# Altium schematic sheet Nucleo-64.SchDoc
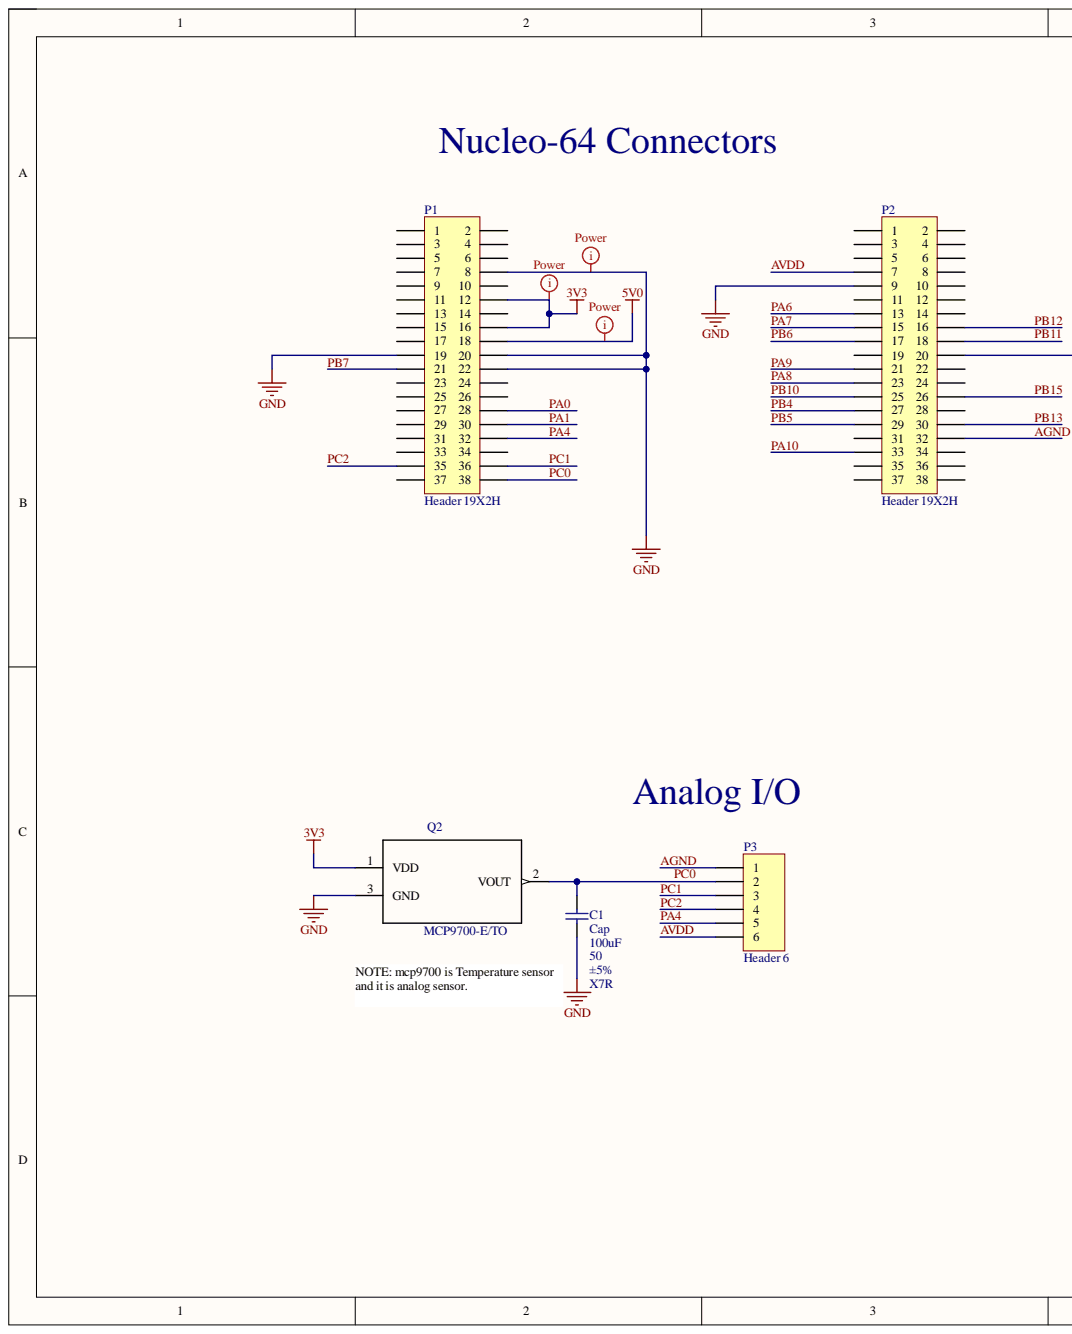
[diagram p0: Nucleo-64.SchDoc, left]
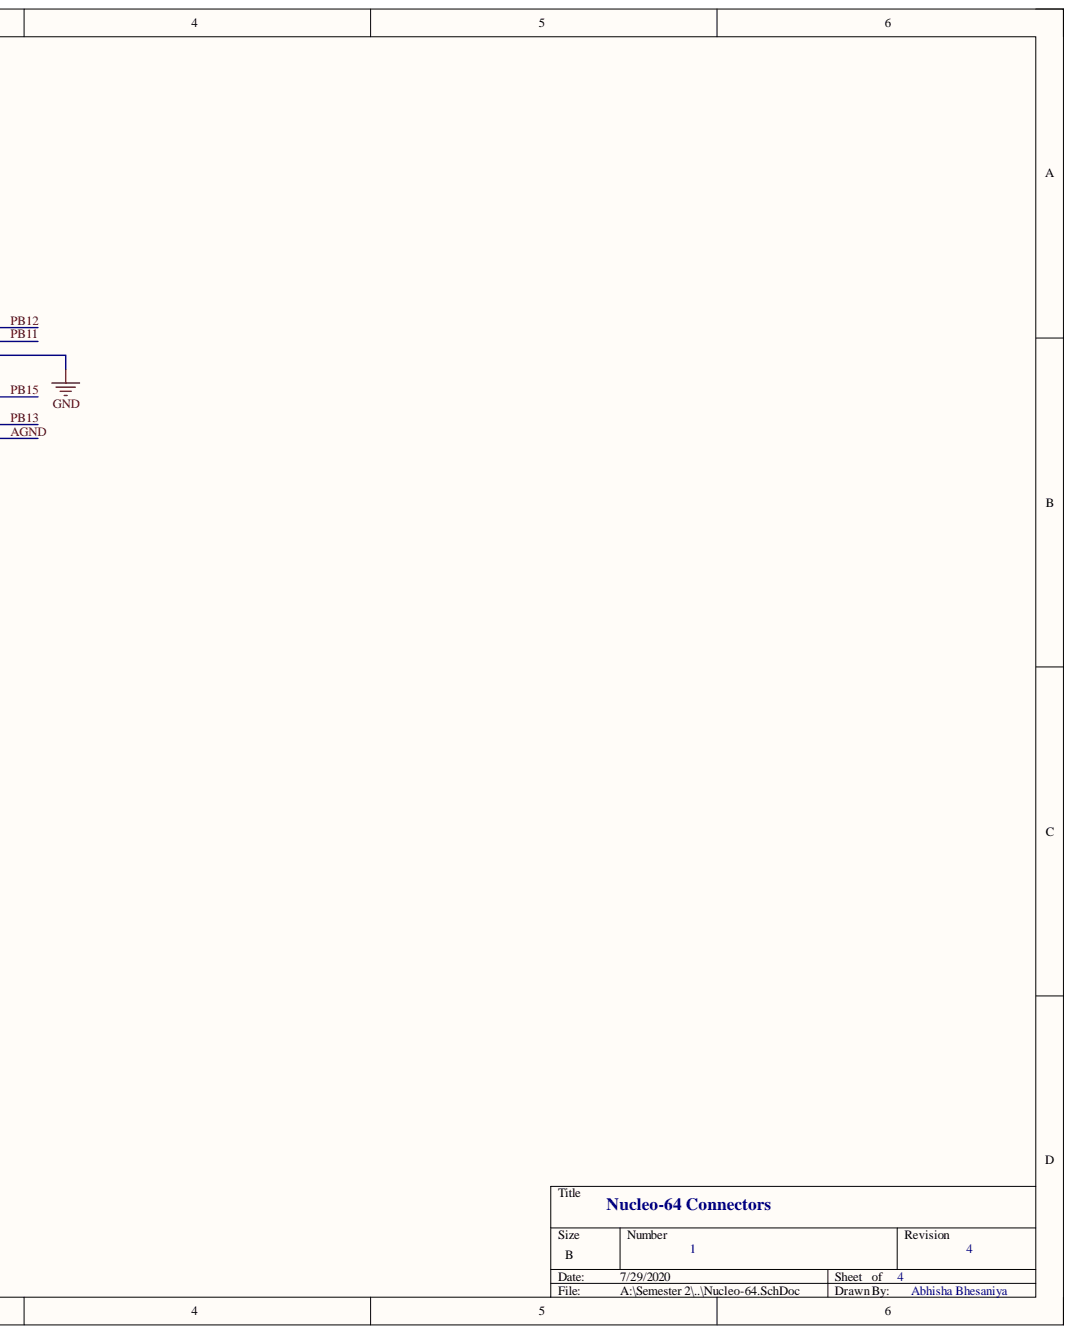
[diagram p1: Nucleo-64.SchDoc, right]

## Parts
C1  Cap  value 100uF  Tolerance: ±5%  [CAPC2012X09M]
P1  Header 19X2H  [PPPC192LFBN-RC]
P2  Header 19X2H  [PPPC192LFBN-RC]
P3  Header 6  [HDR1X6]
Q2  MCP9700-E/TO  [*TO127P254X533-3]

## Nets
3V3: P1.12 P1.16 Q2.1
5V0: P1.18
GND: C1.1 P1.8 P1.19 P1.20 P1.22 P2.9 P2.20 Q2.3
AGND_1: P2.32 P3.1  [also labelled AGND]
AVDD_1: P2.7 P3.6  [also labelled AVDD]
PA0_1: P1.28  [also labelled PA0]
PA1_1: P1.30  [also labelled PA1]
PA4_1: P1.32 P3.5  [also labelled PA4]
PA6_1: P2.13  [also labelled PA6]
PA7_1: P2.15  [also labelled PA7]
PA8_1: P2.23  [also labelled PA8]
PA9_1: P2.21  [also labelled PA9]
PA10_1: P2.33  [also labelled PA10]
PB4_1: P2.27  [also labelled PB4]
PB5_1: P2.29  [also labelled PB5]
PB6_1: P2.17  [also labelled PB6]
PB7_1: P1.21  [also labelled PB7]
PB10_1: P2.25  [also labelled PB10]
PB11_1: P2.18  [also labelled PB11]
PB12_1: P2.16  [also labelled PB12]
PB13_1: P2.30  [also labelled PB13]
PB15_1: P2.26  [also labelled PB15]
PC0_1: C1.2 P1.38 P3.2 Q2.2  [also labelled PC0]
PC1_1: P1.36 P3.3  [also labelled PC1]
PC2_1: P1.35 P3.4  [also labelled PC2]

Not connected: P1 1 2 3 4 5 6 7 9 10 11 13 14 15 17 23 24 25 26 27 29 31 33 34 37; P2 1 2 3 4 5 6 8 10 11 12 14 19 22 24 28 31 34 35 36 37 38

## Library data of the parts
C1 = CAP-X7R-.1uF-50V-±5%: CAP CER .1uF 50v X7R 0805; MPN: C0805C104J5RACTU; PN: 399-1171-1-ND; WV: 50; Component Kind: Standard; Library Name: esd_library.IntLib; Name: CAP-X7R-.1uF-50V-±5%; Type: X7R; URL: http://www.digikey.ca/product-detail/en/C0805C104J5RACTU/399-1171-1-ND
P1 P2 = Header 19X2H: 38 Position Header Connector 0.100in (2.54mm) Through Hole Gold; PN: S7122-ND; Component Kind: Standard; Library Name: esd_library.IntLib; MPN: PPPC192LFBN-RC; Signal Integrity: Connector; URL: https://www.digikey.ca/product-detail/en/sullins-connector-solutions/PPPC192LFBN-RC/S7122-ND
P3 = Header 6: Header, 6-Pin Male; MPN: PEC06SFAN; PN: S1212E-06-ND; Component Kind: Standard; Library Name: esd_library.IntLib; URL: http://www.digikey.ca/product-detail/en/PEC06SFAN/S1212E-06-ND
Q2 = MCP9700-E/TO: Temperature Sensor Analog, Local -40Â°C ~ 125Â°C 10mV/Â°C TO-92-3; MF: Microchip; Arrow_Electronics-Purchase-URL: https://snapeda.com/shop?store=Arrow+Electronics&id=49024; Availability: Unavailable; Component Kind: Standard; DigiKey-Purchase-URL: https://snapeda.com/shop?store=DigiKey&id=49024; Library Name: MCP9700-E_TO.IntLib; Mouser-Purchase-URL: https://snapeda.com/shop?store=Mouser&id=49024; MP: MCP9700-E/TO; Package: TO-92 Microchip; Price: None; SnapEDA_Link: https://www.snapeda.com/parts/MCP9700-E/TO/Microchip/view-part/49024/?ref=snap
